# Altium schematic sheet chipshouter-pico-hv.SchDoc
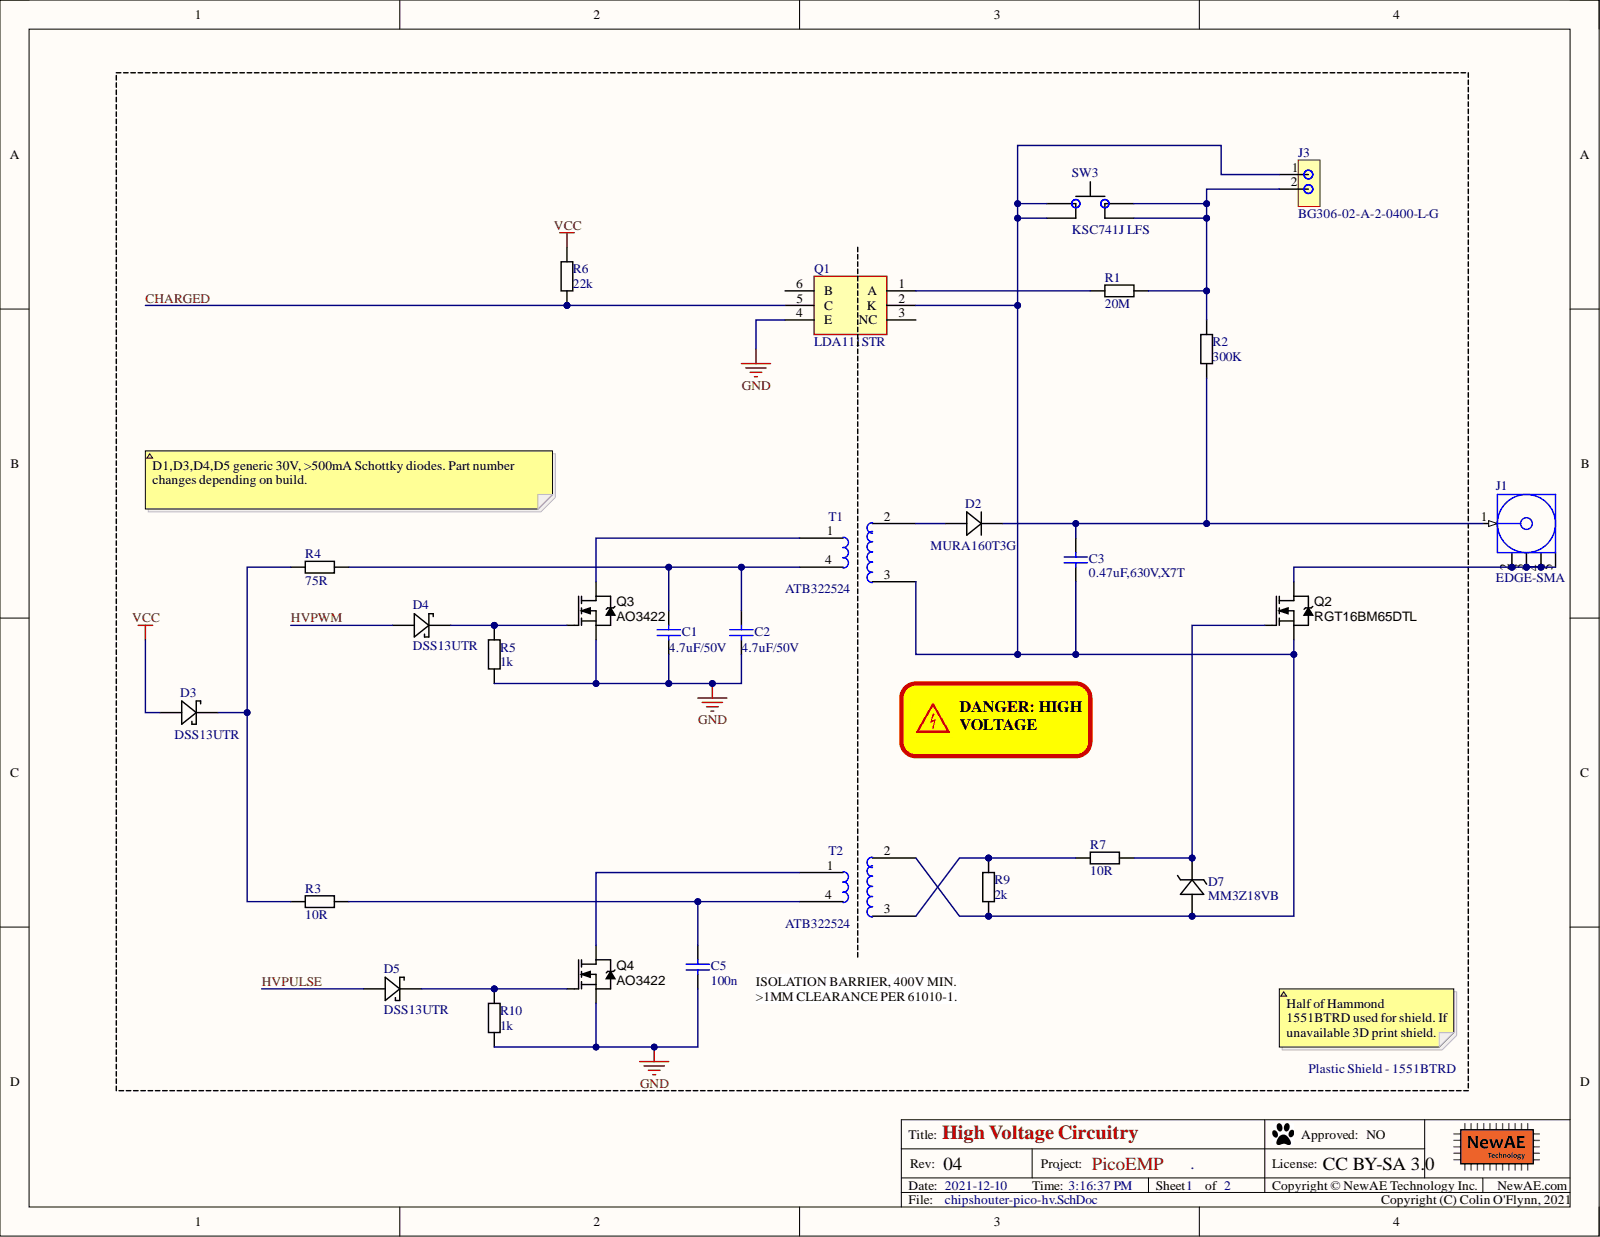
[diagram p0: chipshouter-pico-hv.SchDoc]

## Parts
C1  4.7uF/50V  [0805]
C2  4.7uF/50V  [0805]
C3  0.47uF,630V,X7T  [CKG57N_2220]
C5  100n  [0603]
D2  MURA160T3G  [SMA]
D3  DSS13UTR  [DSS13UTR]
D4  DSS13UTR  [DSS13UTR]
D5  DSS13UTR  [DSS13UTR]
D7  MM3Z18VB  [SOD323]
J1  EDGE-SMA  [CON-SMA-MALE-PCB-EDGE062]
J3  BG306-02-A-2-0400-L-G  [BG306-R2]
Q1  LDA111STR  [SOP254P952X470-6N]
Q2  RGT16BM65DTL  [DPAK]
Q3  AO3422  [SOT23]
Q4  AO3422  [SOT23]
R1  20M  [2010]
R2  300K  [2512]
R3  10R  [0603]
R4  75R  [0805]
R5  1k  [0603]
R6  22k  [0603]
R7  10R  [0603]
R9  2k  [0805]
R10  1k  [0603]
SW3  KSC741J LFS  [CK_KSC7J]
T1  ATB322524  [ATB322524]
T2  ATB322524  [ATB322524]

## Nets
GND: C1.2 C2.2 C5.2 Q1.4(E) Q3.2 Q4.2 R5.2 R10.2
VCC: D3.A R6.1
CHARGED: Q1.5(C) R6.2
HVPULSE: D5.A
HVPWM: D4.A
NetC1_1: C1.1 C2.1 R4.2 T1.4
NetC3_1: C3.1 D2.K J1.1 R2.2
NetC3_2: C3.2 D7.A J3.1 Q1.2(K) Q2.2 R9.2 SW3.1 SW3.4 T1.3 T2.2
NetC5_1: C5.1 R3.2 T2.4
NetD2_A: D2.A T1.2
NetD3_K: D3.K R3.1 R4.1
NetD4_K: D4.K Q3.1 R5.1
NetD5_K: D5.K Q4.1 R10.1
NetD7_K: D7.K Q2.1 R7.2
NetJ1_2: J1.2 J1.3 J1.4 J1.5 Q2.3
NetJ3_2: J3.2 R1.2 R2.1 SW3.2 SW3.3
NetQ1_1: Q1.1(A) R1.1
NetQ3_3: Q3.3 T1.1
NetQ4_3: Q4.3 T2.1
NetR7_1: R7.1 R9.1 T2.3

Not connected: Q1 3(NC) 6(B)

## Library data of the parts
C1 C2 = CCHIP-4u7-0805-50V: 4u7, 50V, 0805, Ceramic; Manufacture 1: TDK Corporation; Manufacture Part Number 1: C2012X5R1H475K125AB; Case-Metric: 1608; Component Group: Capacitor; Database Table Name: main; Rated Voltage: 50VDC; Tolerance: 10%; Value: 4.7uF; Case-EIA: 0805; Component Kind: Standard; Component Type: Standard; Library Name: NewAE_AltiumLib.DBLib; Mounting Technology: SMT; Part Number: CCHIP-4u7-0805-50V; Pin Count: 2; RoHS: Yes; Supplier 1: Digi-Key; Supplier Part Number 1: 445-5980-1-ND; Temperature Range: -55C to 85C
C3 = CKG57NX7T2J105M500JH: 1uF, 630V, X7T, Stacked; Manufacture 1: TDK Corporation; Manufacture Part Number 1: KRM55TR72J474MH01K; Case-EIA: 2220; Case-Metric: 5750; Component Group: Capacitor; Database Table Name: main; Tolerance: +22/-30; Component Kind: Standard; Component Type: Standard; ComponentLink1Description: Tech Spec Page; ComponentLink1URL: https://product.tdk.com/en/search/capacitor/ceramic/mlcc/info?part_no=CKG57NX7T2J105M500JJ; Library Name: NewAE_AltiumLib.DBLib; Part Number: KRM55TR72J474MH01K; Pin Count: 2; Rated Voltage: 630V; Supplier 1: Digi-Key; Supplier Part Number 1: 445-7110-1-ND; Value: 0.47uF, 630V, X7T
C5 = CCHIP-100n-0603: 100n, 0603; Manufacture 1: Kemet; Manufacture Part Number 1: C0603C104K5RACTU; Case-Metric: 1608; Component Group: Capacitor; Database Table Name: main; Rated Voltage: 50VDC; Case-EIA: 0603; Component Kind: Standard; Component Type: Standard; Library Name: NewAE_AltiumLib.DBLib; Mounting Technology: SMT; Part Number: CCHIP-100n-0603; Pin Count: 2; Supplier 1: Digi-Key; Supplier Part Number 1: 399-5089-2-ND; Value: 100n
D2 = MURA160T3G: 600V, 2A, Fast Recovery Diode; Manufacture 1: On Semi; Database Table Name: main; Component Group: Diode; Component Kind: Standard; Component Type: Standard; Library Name: NewAE_AltiumLib.DBLib; Manufacture Part Number 1: MURA160T3G; Mounting Technology: SMT; Part Number: MURA160T3G; Pin Count: 2; Rated Power: 2A; Rated Voltage: 600V; RoHS: Yes; Supplier 1: Digi-Key; Supplier Part Number 1: MURA160T3GOSCT-ND; Temperature Range: -65C to 175C
D3-D5 = DSS13UTR: SCHOTTKY 30V SOD-123FL; Manufacture 1: SMC Diode Solutions; Component Group: Diode; Database Table Name: main; PP Material Stack: 0; Component Kind: Standard; Component Type: Standard; Library Name: NewAE_AltiumLib.DBLib; Manufacture Part Number 1: DSS13UTR; Mounting Technology: SMT; Part Number: DSS13UTR; Pin Count: 2; PP Rotation: 0; RoHS: Yes; Supplier 1: Digi-Key; Supplier Part Number 1: 1655-1926-1-ND
D7 = PDZ10B: DIODE ZENER 10V 400MW SOD323; Manufacture 1: On Semiconductor; Database Table Name: main; PP Material Stack: 0; Rated Power: 0.4W; Tolerance: 2%; Component Group: Diode; Component Kind: Standard; Component Type: Standard; Library Name: NewAE_AltiumLib.DBLib; Manufacture Part Number 1: MM3Z18VB; Mounting Technology: SMT; Part Number: MM3Z18VB; Pin Count: 2; PP Rotation: 0; Rated Voltage: 10V; RoHS: Yes; Supplier 1: Digi-Key; Supplier 2: Mouser; Supplier Part Number 2: 771-PDZ10B115
J1 = CON-F-SMA-PCBEDGE-003.062: SMA MaleEdge Mount Connector for 0.062" Thick Board; Manufacture 1: Linx Technologies; Manufacture Part Number 1: CONSMA013.062; Database Table Name: main; Component Group: Connector; Component Kind: Standard; Component Type: Standard; ComponentLink1Description: Manufacturer URL; ComponentLink1URL: http://www.linxtechnologies.com/; ComponentLink2Description: Datasheet; ComponentLink2URL: http://www.connectorcity.com/diagrams/SMA/CONSMA003.062.pdf; Library Name: NewAE_AltiumLib.DBLib; Part Number: CON-F-SMA-PCBEDGE-003.062; Pin Count: 5; Supplier 1: Digi-Key; Supplier Part Number 1: CONSMA013.062-ND
J3 = BG306-02-A-2-0400-L-G: 2-Pin SMD, Female Header, 0.1" spacing; Manufacture 1: GCT; Component Group: Connector; Database Table Name: main; PP Material Stack: 0; Component Kind: Standard; Component Type: Standard; Library Name: NewAE_AltiumLib.DBLib; Manufacture Part Number 1: BG306-02-A-2-0400-L-G; Part Number: BG306-02-A-2-0400-L-G; Pin Count: 2; PP Rotation: 0; PP Rotation1: 0; Supplier 1: Digi-Key
Q1 = LDA111STR: Phototransistor; Manufacturer_Name: IXYS SEMICONDUCTOR; Arrow Part Number: LDA111STR; Arrow Price/Stock: https://www.arrow.com/en/products/lda111str/ixys; Component Kind: Standard; Datasheet Link: https://datasheet.datasheetarchive.com/originals/dk/DKDS-13/255385.pdf; Height: 4.699mm; Manufacturer_Part_Number: LDA111STR; Mouser Part Number: 849-LDA111STR; Mouser Price/Stock: https://www.mouser.co.uk/ProductDetail/IXYS-Integrated-Circuits/LDA111STR?qs=lQlU%252BwB40guZJ8WaenQLCg%3D%3D
Q2 = IRLR120TRPBF: MOSFET N-CH 100V 7.7A DPAK; Manufacture 1: Vishay Siliconix; Database Table Name: main; Component Group: MOSFET; Component Kind: Standard; Component Type: Standard; Library Name: NewAE_AltiumLib.DBLib; Manufacture Part Number 1: IRLR120TRPBF; Mounting Technology: SMT; Part Number: IRLR120TRPBF; Pin Count: 3; Rated Voltage: 100V; RoHS: Yes; Supplier 1: Digi-Key; Supplier Part Number 1: IRLR120TRPBFCT-ND
Q3 Q4 = DMN3200U-7: MOSFET N-CH 30V 2.2A SOT23-3; Manufacture 1: Diodes Inc.; Database Table Name: main; PP Material Stack: 0; Rated Power: 3A; Component Group: MOSFET; Component Kind: Standard; Component Type: Standard; Library Name: NewAE_AltiumLib.DBLib; Manufacture Part Number 1: DMN3200U-7; Mounting Technology: SMT; Part Number: DMN3200U-7; Pin Count: 3; PP Rotation: 0; Rated Voltage: 30V; RoHS: Yes; Supplier 1: Digi-Key; Supplier Part Number 1: DMN3200U-7DICT-ND
R1 = RCHIP-20M-2010: 20M, 2010, 1%; Manufacture 1: Vishay; Manufacture Part Number 1: CRMA2010AF20M0FKEF; Case-Metric: 5025; Component Group: Resistor; Database Table Name: main; Rated Power: 0.5W; Rated Voltage: 400VDC; Case-EIA: 2010; Component Kind: Standard; Component Type: Standard; Library Name: NewAE_AltiumLib.DBLib; Mounting Technology: SMT; Part Number: RCHIP-20M-2010; Pin Count: 2; Supplier 1: Digi-Key; Temperature Range: -55C to 155C; Tolerance: 1%; Value: 20M
R2 = RCHIP-300K-2512: 300K res, 2512, 1%, 3W; Manufacture 1: TE Connectivity; Manufacture Part Number 1: 3522300KFT; Case-Metric: 6432; Component Group: Resistor; Database Table Name: main; Rated Voltage: 250V; Case-EIA: 2512; Component Kind: Standard; Component Type: Standard; Library Name: NewAE_AltiumLib.DBLib; Mounting Technology: SMT; Part Number: RCHIP-300K-2512; Pin Count: 2; Rated Power: 3W; RoHS: Yes; Supplier 1: Digi-Key; Supplier Part Number 1: A121215CT-ND; Temperature Range: -55C to 155C; Tolerance: 1%; Value: 300K
R3 R7 = RCHIP-10R-0603: 10R res, 0603, 1%, 1/10W; Manufacture 1: Yageo; Manufacture Part Number 1: RC0603FR-0710RL; Case-Metric: 1608; Component Group: Resistor; Database Table Name: main; Rated Power: 0.1W; Rated Voltage: 75VDC; Case-EIA: 0603; Component Kind: Standard; Component Type: Standard; Library Name: NewAE_AltiumLib.DBLib; Mounting Technology: SMT; Part Number: RCHIP-10R-0603; Pin Count: 2; Supplier 1: Digi-Key; Supplier Part Number 1: 311-10.0HRCT-ND; Temperature Range: -55C to 155C; Tolerance: 1%; Value: 10R
R4 = RCHIP-75R-0805: 75R res, 0805, 1%, 1/8W; Manufacture 1: Yageo; Manufacture Part Number 1: RC0805FR-0775RL; Component Group: Resistor; Database Table Name: main; Rated Power: 0.125W; Rated Voltage: 75VDC; Case-EIA: 0805; Component Kind: Standard; Component Type: Standard; Library Name: NewAE_AltiumLib.DBLib; Mounting Technology: SMT; Part Number: RCHIP-75R-0805; Pin Count: 2; Supplier 1: Digi-Key; Supplier Part Number 1: 311-75.0CRCT-ND; Temperature Range: -55C to 155C; Tolerance: 1%; Value: 75R
R5 R10 = RCHIP-1K-0603: 1k res, 0603, 1%, 1/10W; Manufacture 1: Yageo; Manufacture Part Number 1: RC0603FR-071KL; Case-Metric: 1608; Component Group: Resistor; Database Table Name: main; Rated Power: 0.1W; Rated Voltage: 75VDC; Case-EIA: 0603; Component Kind: Standard; Component Type: Standard; Library Name: NewAE_AltiumLib.DBLib; Mounting Technology: SMT; Part Number: RCHIP-1K-0603; Pin Count: 2; Supplier 1: Digi-Key; Supplier Part Number 1: 311-1.00KHRCT-ND; Temperature Range: -55C to 155C; Tolerance: 1%; Value: 1k
R6 = RCHIP-22K-0603: 22k res, 0603, 1%, 1/10W; Manufacture 1: Yageo; Manufacture Part Number 1: RC0603FR-0722KL; Case-Metric: 1608; Component Group: Resistor; Database Table Name: main; Rated Power: 0.1W; Rated Voltage: 75VDC; Case-EIA: 0603; Component Kind: Standard; Component Type: Standard; Library Name: NewAE_AltiumLib.DBLib; Mounting Technology: SMT; Part Number: RCHIP-22K-0603; Pin Count: 2; Supplier 1: Digi-Key; Supplier Part Number 1: 311-22.0KHRCT-ND; Temperature Range: -55C to 155C; Tolerance: 1%; Value: 22k
R9 = RCHIP-75R-0805: Manufacture 1: Yageo; Manufacture Part Number 1: RC0805FR-0775RL; Component Group: Resistor; Database Table Name: main; Rated Power: 0.125W; Rated Voltage: 75VDC; Tolerance: 1%; Value: 75R; Case-EIA: 0805; Component Kind: Standard; Component Type: Standard; Library Name: NewAE_AltiumLib.DBLib; Mounting Technology: SMT; Part Number: RCHIP-75R-0805; Pin Count: 2; Supplier 1: Digi-Key; Supplier Part Number 1: 311-75.0CRCT-ND; Temperature Range: -55C to 155C
SW3 = TACTSW-KSC741J: SWITCH TACTILE SPST-NO 0.01A 32V; Manufacture 1: C&K; Database Table Name: main; PP Material Stack: 0; Component Group: Switch; Component Kind: Standard; Component Type: Standard; Library Name: NewAE_AltiumLib.DBLib; Manufacture Part Number 1: KSC741J LFS; Mounting Technology: SMT; Part Number: TACTSW-KSC741J; Pin Count: 4; PP Rotation: 0; RoHS: Yes; Supplier 1: Digi-Key; Supplier Part Number 1: CKN10309CT-ND
T1 T2 = ATB322524_PROTO: HV Transformer 1:10, Small; Manufacture 1: TDK; Component Group: Inductor; Database Table Name: main; Component Kind: Standard; Component Type: Standard; Library Name: NewAE_AltiumLib.DBLib; Manufacture Part Number 1: ATB322524; Mounting Technology: SMT; Part Number: ATB322524_PROTO; Pin Count: 4; RoHS: Yes; Supplier 1: Mouser
